# Altium schematic sheet servo_hack.SchDoc
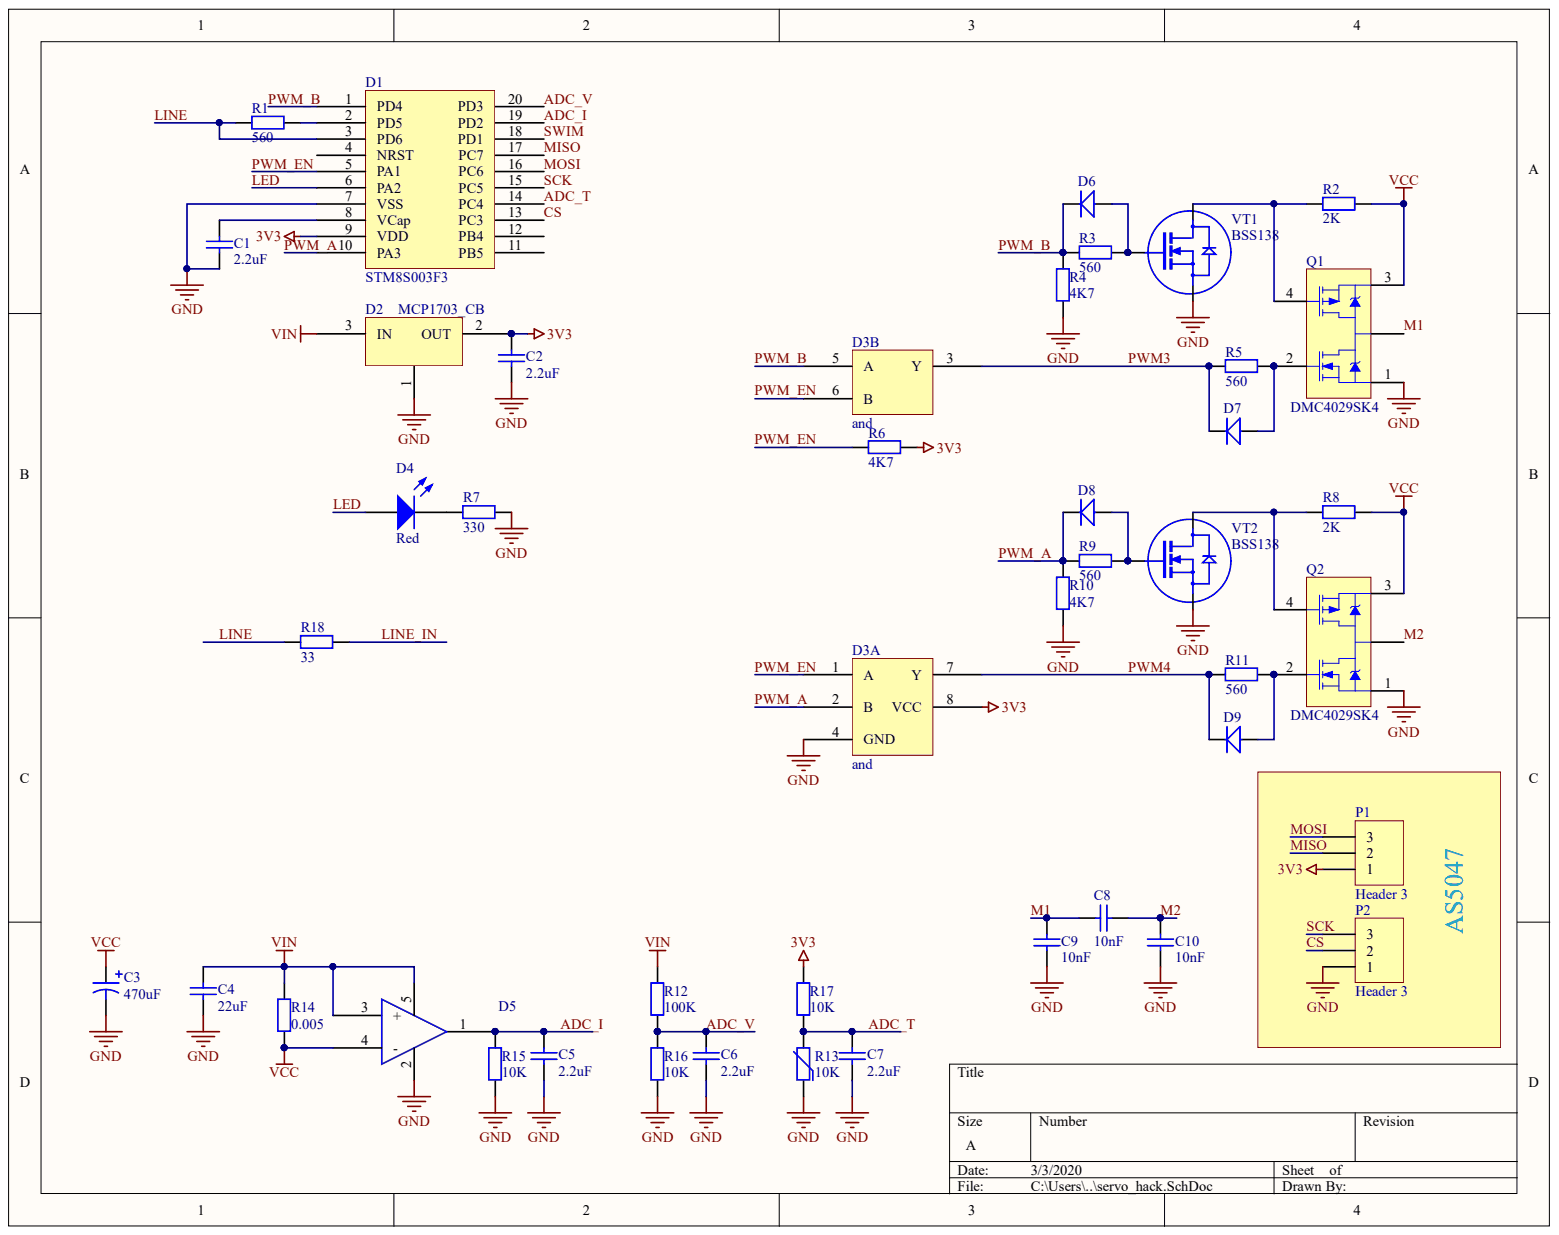
[diagram p0: servo_hack.SchDoc]

## Parts
C1  value 2.2uF  [0603]  (hidden comment: Cap)
C2  value 2.2uF  [0603]  (hidden comment: Cap)
C3  value 470uF  [CAPXON_10]  (hidden comment: Cap Polar)
C4  value 22uF  [1206]  (hidden comment: Cap)
C5  value 2.2uF  [0603]  (hidden comment: Cap)
C6  value 2.2uF  [0603]  (hidden comment: Cap)
C7  value 2.2uF  [0603]  (hidden comment: Cap)
C8  value 10nF  [0603]  (hidden comment: Cap)
C9  value 10nF  [0603]  (hidden comment: Cap)
C10  value 10nF  [0603]  (hidden comment: Cap)
D1  STM8S003F3  [TSOP65P640X110-20N]
D2  MCP1703_CB  [SOT23_3]
D3  and  [VSSOP8]  (parts A,B)
D4  Red  [D0603]
D5  INA138  [R-PDSO-G5]  (value not drawn)
D6  1N4148WS  [SOD-323]  (value not drawn)
D7  1N4148WS  [SOD-323]  (value not drawn)
D8  1N4148WS  [SOD-323]  (value not drawn)
D9  1N4148WS  [SOD-323]  (value not drawn)
P1  Header 3  [HDR1X3]
P2  Header 3  [HDR1X3]
Q1  DMC4029SK4  [DMC4029SK4]
Q2  DMC4029SK4  [DMC4029SK4]
R1  value 560  [0603]  (hidden comment: Res)
R2  value 2K  [0603]  (hidden comment: Res)
R3  value 560  [0603]  (hidden comment: Res)
R4  value 4K7  [0603]  (hidden comment: Res)
R5  value 560  [0603]  (hidden comment: Res)
R6  value 4K7  [0603]  (hidden comment: Res)
R7  value 330  [0603]  (hidden comment: Res)
R8  value 2K  [0603]  (hidden comment: Res)
R9  value 560  [0603]  (hidden comment: Res)
R10  value 4K7  [0603]  (hidden comment: Res)
R11  value 560  [0603]  (hidden comment: Res)
R12  value 100K  [0603]  (hidden comment: Res)
R13  Resistance: 10K  [0603]  (hidden comment: Thermistor)
R14  value 0.005  [2512]  (hidden comment: Res)
R15  value 10K  [0603]  (hidden comment: Res)
R16  value 10K  [0603]  (hidden comment: Res)
R17  value 10K  [0603]  (hidden comment: Res)
R18  value 33  [0603]  (hidden comment: Res)
VT1  BSS138  [SOT23-3]
VT2  BSS138  [SOT23-3]

## Nets
3V3: C2.2 D1.9(VDD) D2.2 D3.8(VCC) P1.1 R6.1 R17.2
GND: C1.1 C2.1 C3.2 C4.1 C5.1 C6.1 C7.1 C9.2 C10.1 D1.7(VSS) D2.1 D3.4(GND) D5.2 P2.1 Q1.1 Q2.1 R4.1 R7.1 R10.1 R13.1 R15.1 R16.1 VT1.2 VT2.2
VCC: C3.1 D5.4(-) Q1.3 Q2.3 R2.2 R8.2 R14.1
VIN: C4.2 D2.3 D5.3(+) D5.5 R12.2 R14.2
ADC_I: C5.2 D1.19(PD2) D5.1 R15.2
ADC_T: C7.2 D1.14(PC4) R13.2 R17.1
ADC_V: C6.2 D1.20(PD3) R12.1 R16.2
CS: D1.13(PC3) P2.2
LED: D1.6(PA2) D4.1
LINE: D1.3(PD6) R1.1 R18.1
LINE_IN: R18.2
M1: C8.2 C9.1 Q1.5
M2: C8.1 C10.2 Q2.5
MISO: D1.17(PC7) P1.2
MOSI: D1.16(PC6) P1.3
PWM3: D3.3(Y) D7.2 R5.1
PWM4: D3.7(Y) D9.2 R11.1
PWM_A: D1.10(PA3) D3.2(B) D8.2 R9.1 R10.2
PWM_B: D1.1(PD4) D3.5(A) D6.2 R3.1 R4.2
PWM_EN: D1.5(PA1) D3.1(A) D3.6(B) R6.2
SCK: D1.15(PC5) P2.3
SWIM: D1.18(PD1)
NetC1_2: C1.2 D1.8(VCap)
NetD1_2: D1.2(PD5) R1.2
NetD4_2: D4.2 R7.2
NetD6_1: D6.1 R3.2 VT1.1
NetD7_1: D7.1 Q1.2 R5.2
NetD8_1: D8.1 R9.2 VT2.1
NetD9_1: D9.1 Q2.2 R11.2
NetQ1_4: Q1.4 R2.1 VT1.3
NetQ2_4: Q2.4 R8.1 VT2.3

Not connected: D1 4(NRST) 11(PB5) 12(PB4)

## Library data of the parts
C1 C2 C5-C7 = C1608X5R1C225M@TDK: Capacitor; Database Table Name: Capacitor ceramic; Tolerance: 20%; Voltage rated: 16V; Component Kind: Standard; Library Name: passive.DbLib; Link: http://www.elitan.ru/price/item1198338; Package: 0603; Part Number: C1608X5R1C225M@TDK; Supplier: elitan.ru
C3 = EEVFK1V471Q: Polar capacitor; Database Table Name: Capacitor polar; Tolerance: 10%; Voltage rated: 35V; Component Kind: Standard; Library Name: passive.DbLib; Package: CAPXON_10; Part Number: EEVFK1V471Q
C4 = GRM31CR61E226KE15L@MURATA: Capacitor; Database Table Name: Capacitor ceramic; Tolerance: 10%; Voltage rated: 25V; Component Kind: Standard; Library Name: passive.DbLib; Link: http://www.elitan.ru/price/item4546741; Package: 1206; Part Number: GRM31CR61E226KE15L@MURATA; Supplier: elitan.ru
C8-C10 = CC0603JRX7R9BB103@YAGEO: Capacitor; Database Table Name: Capacitor ceramic; Tolerance: 5%; Voltage rated: 50V; Component Kind: Standard; Library Name: passive.DbLib; Link: http://www.elitan.ru/price/item629684; Package: 0603; Part Number: CC0603JRX7R9BB103@YAGEO; Supplier: elitan.ru
D1 = STM8S003F3@ST: Database Table Name: MCU-STM8; Component Kind: Standard; Library Name: generic ic.DbLib; Part Number: STM8S003F3@ST
D2 = MCP1703T-3302E/CB@MICROCHIP: Linear Reg; Current: 0.10A; Database Table Name: Voltage Regulators - Linear; Voltage out: 3.3V; Component Kind: Standard; Library Name: generic ic.DbLib; Link: http://www.elitan.ru/price/item669279; Package: SOT23; Part Number: MCP1703T-3302E/CB@MICROCHIP; Supplier: elitan.ru
D3 = SN74AHC2G08DC: Part Number: 74AUP2G02DC; Database Table Name: General logic 74HC***; Component Kind: Standard; Default Comment: SN74AHC2G08; Library Name: generic ic.DbLib; Package: VSSOP8
D4 = LTST-C191KRKT@LITEON: Database Table Name: Led; Component Kind: Standard; Library Name: discrete.DbLib; Part Number: LTST-C191KRKT@LITEON; Supplier: elitan.ru
D5 = INA138NA@TI: Database Table Name: Current sensors; Component Kind: Standard; Default Comment: INA138; Library Name: generic ic.DbLib; Link: http://www.elitan.ru/price/item94391; Package: SOT-23; Part Number: INA138NA@TI; Supplier: elitan.ru
D6-D9 = 1N4148WS@DIOTEC: Database Table Name: Diode tiny; Rated Voltage: 75V; Component Kind: Standard; Library Name: discrete.DbLib; Link: http://www.elitan.ru/price/item261580; Package: SOD-323 / 323; Part Number: 1N4148WS@DIOTEC; Supplier: elitan.ru
P1 P2 = Header 3: Header, 3-Pin; Component Kind: Standard; LatestRevisionDate: 17-Jul-2002; LatestRevisionNote: Re-released for DXP Platform.; Library Name: Miscellaneous Connectors.IntLib; Signal Integrity: Connector; Publisher: Altium Limited
Q1 Q2 = DMC4029SK4: Database Table Name: MOSFEET power; Rated Current: 5A; Rated Voltage: 40V; Component Kind: Standard; Description: DMC4029SK4; Library Name: discrete.DbLib; Part Number: DMC4029SK4
R1 R3 R5 R9 R11 = R_00028: Resistor; Tolerance: 5%; Component Kind: Standard; Database Table Name: Resistor 0603; Library Name: passive.DbLib; Package: 0603; Part Number: R_00028; Supplier: elitan.ru
R2 R8 = R_00036: Resistor; Tolerance: 5%; Component Kind: Standard; Database Table Name: Resistor 0603; Library Name: passive.DbLib; Package: 0603; Part Number: R_00036; Supplier: elitan.ru
R4 R6 R10 = R_00042: Resistor; Tolerance: 5%; Component Kind: Standard; Database Table Name: Resistor 0603; Library Name: passive.DbLib; Package: 0603; Part Number: R_00042; Supplier: elitan.ru
R7 = R_00024: Resistor; Tolerance: 5%; Component Kind: Standard; Database Table Name: Resistor 0603; Library Name: passive.DbLib; Package: 0603; Part Number: R_00024; Supplier: elitan.ru
R12 = R_00065: Resistor; Tolerance: 5%; Component Kind: Standard; Database Table Name: Resistor 0603; Library Name: passive.DbLib; Package: 0603; Part Number: R_00065; Supplier: elitan.ru
R13 = NCP18XH103F03RB@MURATA: Accuracy: +-1; Max Temp: 125C; Min Temp: -40C; Tolerance: 1% (25C); Component Kind: Standard; Database Table Name: Thermistor; Description: Thermistor; Library Name: passive.DbLib; Link: http://www.elitan.ru/price/item511845; Package: 0603; Part Number: NCP18XH103F03RB@MURATA; Supplier: elitan.ru
R14 = CRE2512-FZ-R005E-3: Resistor; Database Table Name: Shunt; Tolerance: 1%; Component Kind: Standard; Library Name: passive.DbLib; Part Number: CRE2512-FZ-R005E-3
R15-R17 = R_00049: Resistor; Tolerance: 5%; Component Kind: Standard; Database Table Name: Resistor 0603; Library Name: passive.DbLib; Package: 0603; Part Number: R_00049; Supplier: elitan.ru
R18 = R_00008: Resistor; Tolerance: 5%; Component Kind: Standard; Database Table Name: Resistor 0603; Library Name: passive.DbLib; Package: 0603; Part Number: R_00008; Supplier: elitan.ru
VT1 VT2 = BSS138: Database Table Name: MOSFEET power; On-Resistance: 6 Oh; Rated Current: 0.22; Rated Voltage: 50V; Component Kind: Standard; Library Name: discrete.DbLib; Package: BSS138; Part Number: BSS138; Supplier: eliten
